# Altium schematic sheet Sensors.SchDoc
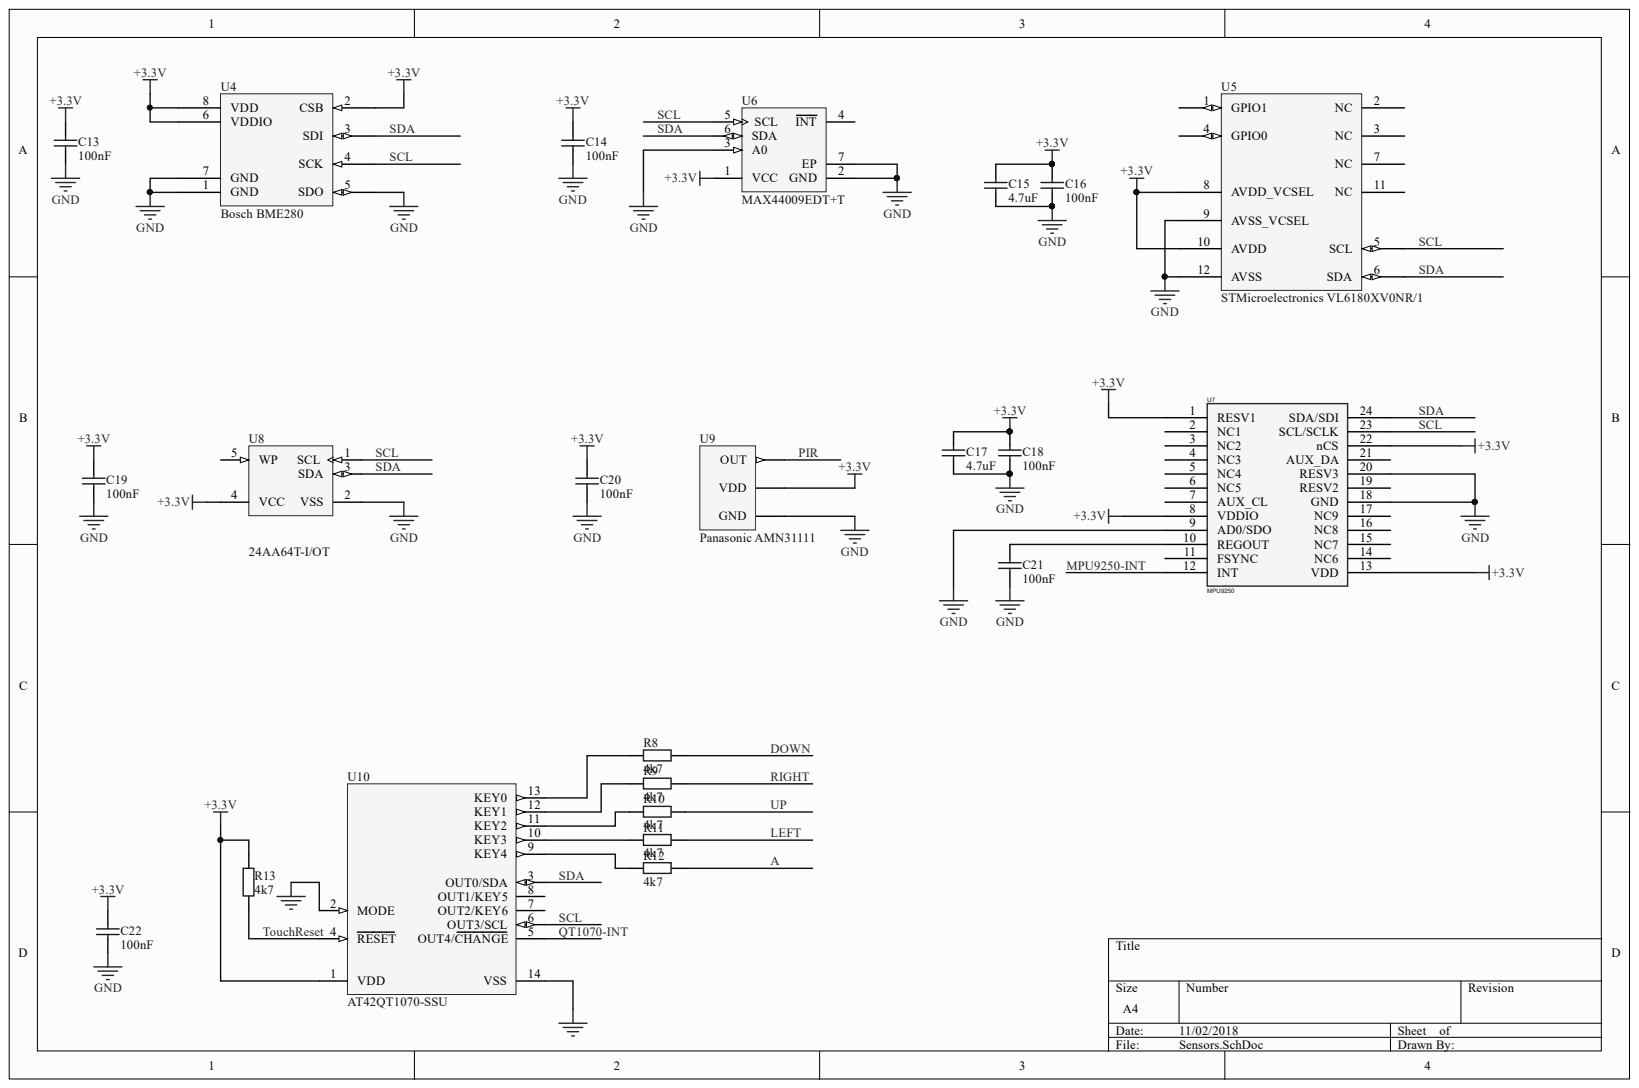
[diagram p0: Sensors.SchDoc]

## Parts
C13  100nF  [PCB-14j6yrx10pagyq47cjuv-1]
C14  100nF  [PCB-14j6yrx10pagyq47cjuv-1]
C15  4.7uF  [PCB-vop812zmyolprsjr0cjz-1]
C16  100nF  [PCB-14j6yrx10pagyq47cjuv-1]
C17  4.7uF  [PCB-vop812zmyolprsjr0cjz-1]
C18  100nF  [PCB-14j6yrx10pagyq47cjuv-1]
C19  100nF  [PCB-14j6yrx10pagyq47cjuv-1]
C20  100nF  [PCB-14j6yrx10pagyq47cjuv-1]
C21  100nF  [PCB-14j6yrx10pagyq47cjuv-1]
C22  100nF  [PCB-14j6yrx10pagyq47cjuv-1]
R8  4k7  [PCB-1vayfl3026fycqkmw7iz-1]
R9  4k7  [PCB-1vayfl3026fycqkmw7iz-1]
R10  4k7  [PCB-1vayfl3026fycqkmw7iz-1]
R11  4k7  [PCB-1vayfl3026fycqkmw7iz-1]
R12  4k7  [PCB-1vayfl3026fycqkmw7iz-1]
R13  4k7  [PCB-1vayfl3026fycqkmw7iz-1]
U4  Bosch BME280  [PCB-ndfzofm3vevwltuf4ahj-1]
U5  STMicroelectronics VL6180XV0NR/1  [PCBComponent_1]
U6  MAX44009EDT+T  [MAXM-D622N+4_V]
U7  MPU9250  [QFN40P305X305X105_HS-25N]
U8  24AA64T-I/OT  [SOT-23-OT5_N]
U9  Panasonic AMN31111  [PCB-x32ypyt9e2pwgcswqnkg-1]
U10  AT42QT1070-SSU  [14S1_N]

## Nets
+3.3V: C13.1 C14.1 C15.2 C16.1 C17.2 C18.1 C19.1 C20.1 C22.1 R13.2 U4.2(CSB) U4.6(VDDIO) U4.8(VDD) U5.8(AVDD_VCSEL) U5.10(AVDD) U6.1(VCC) U7.1(RESV1) U7.8(VDDIO) U7.13(VDD) U7.22(nCS) U8.4(VCC) U9.1 U10.1(VDD)
GND: C13.2 C14.2 C15.1 C16.2 C17.1 C18.2 C19.2 C20.2 C21.2 C22.2 U4.1(GND) U4.5(SDO) U4.7(GND) U5.9(AVSS_VCSEL) U5.12(AVSS) U6.2(GND) U6.3(A0) U6.7(EP) U7.9(AD0/SDO) U7.18(GND) U7.20(RESV3) U8.2(VSS) U9.2 U10.2(MODE) U10.14(VSS)
A: R12.1
DOWN: R8.1
LEFT: R11.1
MPU9250-INT: U7.12(INT)
PIR: U9.3
QT1070-INT: U10.5(OUT4/CHANGE)
RIGHT: R9.1
SCL: U4.4(SCK) U5.5(SCL) U6.5(SCL) U7.23(SCL/SCLK) U8.1(SCL) U10.6(OUT3/SCL)
SDA: U4.3(SDI) U5.6(SDA) U6.6(SDA) U7.24(SDA/SDI) U8.3(SDA) U10.3(OUT0/SDA)
TOUCHRESET: R13.1 U10.4(RESET)
UP: R10.1
NetC21_1: C21.1 U7.10(REGOUT)
NetR8_2: R8.2 U10.13(KEY0)
NetR9_2: R9.2 U10.12(KEY1)
NetR10_2: R10.2 U10.11(KEY2)
NetR11_2: R11.2 U10.10(KEY3)
NetR12_2: R12.2 U10.9(KEY4)

Not connected: U5 1(GPIO1) 2(NC) 3(NC) 4(GPIO0) 7(NC) 11(NC); U6 4(INT); U7 2(NC1) 3(NC2) 4(NC3) 5(NC4) 6(NC5) 7(AUX_CL) 11(FSYNC) 14(NC6) 15(NC7) 16(NC8) 17(NC9) 19(RESV2) 21(AUX_DA); U8 5(WP); U10 7(OUT2/KEY6) 8(OUT1/KEY5)

## Library data of the parts
C13 C14 C16 C18-C22 = CMP-cab80115e1b0157f-1: 0603 1 uF 10 V ±10 % Tol. X5R Surface Mount Multilayer Ceramic Capacitor; Capacitance Tolerance: ±10%; Lead-Free Status: Lead Free; Voltage Rating (DC): 10.0 V; Case/Package: 0603; Component Kind: Standard; Dielectric Characteristic: X5R; Library Name: CommunityVault; Mounting Style: Surface Mount; OctopartID: cab80115e1b0157f; Octopart URL: http://octopart.com/0603x105k100ct-walsin+technologies-44502638; Packaging: Cut Tape (CT); RoHS: Compliant
C15 C17 = CMP-0b47c3f374bad480-1: Cap, Mlcc, X5r, 4.7uf, 10v, 0603; Voltage Rating (DC): 10.0 V; Component Kind: Standard; Dielectric Characteristic: X5R; Library Name: CommunityVault; REACH SVHC Compliance: No SVHC; RoHS: Compliant
R8-R13 = CMP-91545b5de2944e93-1: Surface Mount Thick Film Resistor, MCWR Series, 4.7 kohm, 100 mW, - 1%, 50 V; Manufacturer Part Number: MCWR06X4701FTL; Case/Package (SI): 1608; Power Rating: 100 mW; Resistance: 4.70 kΩ; Case/Package: 0603, 1608; Component Kind: Standard; Library Name: CommunityVault; OctopartID: 91545b5de2944e93; Octopart URL: http://octopart.com/mcwr06x4701ftl-multicomp-50550438; Packaging: Tape & Reel (TR), Cut Tape (CT); REACH SVHC Compliance: No SVHC; Resistance Tolerance: ±1%; RoHS: Compliant
U4 = CMP-19345792-7: BME280 1.71 to 3.6 V Combined Humidity and Pressure Sensor - LGA-8; Lead-Free Status: Lead Free; Component Kind: Standard; Library Name: CommunityVault; OctopartID: a1c26bef29297e05; Octopart URL: http://octopart.com/bme280-bosch+tools-52931981; RoHS: Compliant
U5 = CMP-e1d22a13db0e3e15-11: VL6180X Series 3 V Proximity and Ambient Light Sensing (ALS) Module - LGA-12; Lead-Free Status: Lead Free; Component Kind: Standard; Library Name: CommunityVault; REACH SVHC Compliance: No SVHC
U6 = CMP-2127511-3: Industry's Lowest-Power Digital Ambient Light Sensor with I2C Output, 1.7 to 3.6 V, -40 to 85 degC, 6-Pin SON (D622N+4), Pb-Free, Tape and Reel; Component Kind: Standard; Library Name: CommunityVault; Lifecycle Status: Active; Manufacturer Part Number: MAX44009EDT+T; OctopartID: e71f2cb0f32b38d8; Octopart Url: https://octopart.com/max44009edt%2Bt-maxim+integrated-44380498
U7 = CMP-14871381-25: Nine-Axis (Gyro + Accelerometer + Compass) MEMS MotionTracking Device; Component Kind: Standard; Library Name: CommunityVault; Mounting Style: Surface Mount; OctopartID: beb076465eb06177; Octopart URL: http://octopart.com/mpu-9250-invensense-33342822; RoHS: Compliant
U8 = CMP-971312-1: 64Kbit, 400kHz, 1.7V, I2C Serial EEPROM, 5-Pin SOT-23, Industrial Temperature, Tape and Reel; Access Time (Max): 3500 ns; CiivaID: 971312; Data Retention: 200; Density: 65536 Bit; Frequency: 0.1 MHz; Interface Type: Serial (I2C); Operating Supply Voltage (Max): 5.5 V; Operating Supply Voltage (Typ): 1.8/2.5/3.3/5 V; Organization: 8Kx8; Programmable: Yes; Rad Hardened: No; Supply Current: 3 mA; Ciiva URL: https://ciiva.com/part/24AA64T-I/OT-971312; Component Kind: Standard; Library Name: CommunityVault; Mounting: Surface Mount; Operating Supply Voltage (Min): 1.7 V; Operating Temperature (Max): 85C; Operating Temperature (Min): -40C; Operating Temperature Classification: Industrial; Operating Temp Range: -40C to 85C; Package Type: SOT-23; Packaging: Tape and Reel; Pin Count: 5; Write Protection: Yes
U9 = CMP-2506ec50032afac1-3: AMN3 Series 3 V Digital Out Passive Black Lens Infrared Motion Sensor; Component Kind: Standard; Library Name: CommunityVault
U10 = CMP-978227-2: QTouch 7-channel Sensor IC, -40° to +85° C, 14-Pin SOIC; Lead-Free Status: Lead Free; Component Kind: Standard; Library Name: CommunityVault; Number of Pins: 14; OctopartID: 587a93d54fde87bd; Octopart Url: https://octopart.com/at42qt1070-ssu-atmel-44380866; REACH SVHC Compliance: No SVHC; RoHS: Compliant
